# Altium schematic sheet RPI1.SchDoc
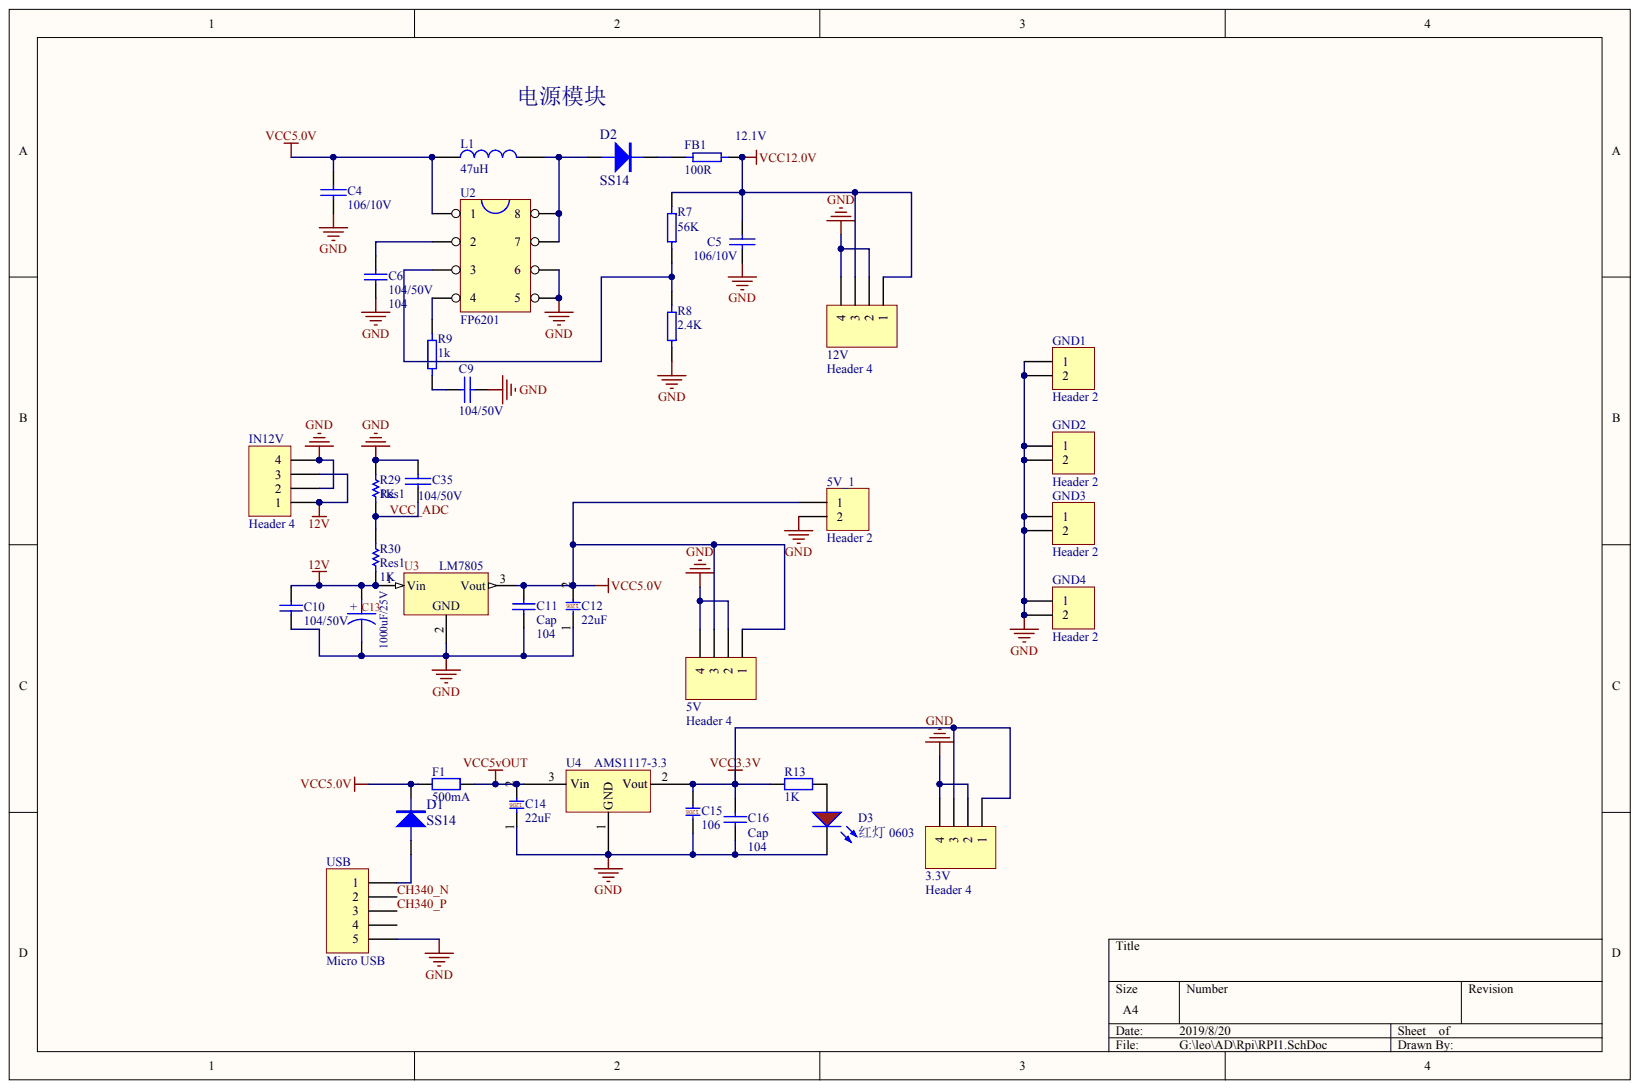
[diagram p0: RPI1.SchDoc]

## Parts
3.3V  Header 4  [KF350-4P]
5V  Header 4  [KF350-4P]
5V_1  Header 2  [HDR1X2]
12V  Header 4  [KF350-4P]
C4  106/10V  [1206c]
C5  106/10V  [1206c]
C6  104/50V  value 104  [0603C]
C9  104/50V  [0603C]
C10  104/50V  [0805]
C11  Cap  value 104  [0805C]
C12  22uF  [1206c]
C13  1000uF/25V  [CAP-10x20-5mm - Vertical]
C14  22uF  [1206c]
C15  106  [1206C]
C16  Cap  value 104  [0805C]
C35  104/50V  [0805c]
D1  SS14  [SMA]
D2  SS14  [SMA]
D3  红灯 0603  [0603_LED_S1]
F1  500mA  [0805FUSE]
FB1  100R  [1206R]
GND1  Header 2  [HDR1X2]
GND2  Header 2  [HDR1X2]
GND3  Header 2  [HDR1X2]
GND4  Header 2  [HDR1X2]
IN12V  Header 4  [KF350-4P]
L1  47uH  [L 50*40]
R7  56K  [0603R]
R8  2.4K  [0603R]
R9  1k  [0603R]
R13  1K  [0603R]
R29  Res1  value 1K  [0805R]
R30  Res1  value 1K  [0805R]
U2  FP6201  [SO-8]
U3  LM7805  [TO252]
U4  AMS1117-3.3  [SOT-223]
USB  Micro USB  [Micro USB]

## Nets
12V: C10.1 C13.1 IN12V.1 IN12V.3 R30.1 U3.1
GND: 3.3V.2 3.3V.4 5V.2 5V.4 5V_1.2 12V.2 12V.4 C4.2 C5.2 C6.2 C9.1 C10.2 C11.2 C12.1 C13.2 C14.1 C15.1 C16.2 C35.2 D3.K GND1.1 GND1.2 GND2.1 GND2.2 GND3.1 GND3.2 GND4.1 GND4.2 IN12V.2 IN12V.4 R8.1 R29.2 U2.5 U2.6 U3.2 U4.1 USB.5
VCC3.3V: 3.3V.1 3.3V.3 C15.2 C16.1 R13.2 U4.2
VCC5.0V: 5V.1 5V.3 5V_1.1 C4.1 C11.1 C12.2 D1.2 F1.2 L1.1 U2.1 U3.3
VCC5VOUT: C14.2 F1.1 U4.3
VCC12.0V: 12V.1 12V.3 C5.1 FB1.1 R7.2
CH340_N: USB.2
CH340_P: USB.3
VCC_ADC: C35.1 R29.1 R30.2
NetC6_1: C6.1 U2.2
NetC9_2: C9.2 R9.1
NetD1_1: D1.1 USB.1
NetD2_1: D2.1 L1.2 U2.7 U2.8
NetD2_2: D2.2 FB1.2
NetD3_A: D3.A R13.1
NetR7_1: R7.1 R8.2 U2.3
NetR9_2: R9.2 U2.4

Not connected: USB 4

## Library data of the parts
3.3V 5V 12V IN12V = Header 4: Header, 4-Pin; Component Kind: Standard; LatestRevisionDate: 17-Jul-2002; LatestRevisionNote: Re-released for DXP Platform.; Library Name: Miscellaneous Connectors.IntLib; Signal Integrity: Connector; Publisher: Altium Limited
5V_1 GND1-GND4 = Header 2: Header, 2-Pin; Component Kind: Standard; LatestRevisionDate: 17-Jul-2002; LatestRevisionNote: Re-released for DXP Platform.; Library Name: Miscellaneous Connectors.IntLib; Signal Integrity: Connector; Publisher: Altium Limited
C4 C5 C9 C13 C35 = CAP: Capacitor; Component Kind: Standard
  C4 C5: Library Name: Wireless module.SCHLIB
  C13: Library Name: Commonly used libraries.SCHLIB
C6 C10 C11 C16 = Cap: Capacitor; Component Kind: Standard; LatestRevisionDate: 17-Jul-2002; LatestRevisionNote: Re-released for DXP Platform.; Library Name: Miscellaneous Devices.IntLib; PackageReference: RAD-0.3; Published: 8-Jun-2000; Signal Integrity: Cap; Simulation: CAP; Publisher: Altium Limited
C12 C14 C15 = Cap_1206: Capacitor; Component Kind: Standard; Library Name: LZ PcbLib - ALL.SchLib
D1 D2 = DIODE: Component Kind: Standard; Description: Diode; Library Name: Wireless module.SCHLIB
D3 = 0603 红灯: 发光二极管; Component Kind: Standard; ComponentLink1Description: 供应商链接; ComponentLink1URL: http://www.szlcsc.com/product/details_2659.html; Library Name: LED.SchLib; Package: 0603; Supplier: LC; SuppliersPartNumber: C2286
F1 R13 = Res2: Resistor; Component Kind: Standard; LatestRevisionDate: 17-Jul-2002; LatestRevisionNote: Re-released for DXP Platform.; PackageReference: AXIAL-0.4; Published: 8-Jun-2000; Publisher: Altium Limited
FB1 R7-R9 = RES: Component Kind: Standard; Library Name: Wireless module.SCHLIB
L1 = INDUCTOR: Component Kind: Standard
R29 R30 = Res1: Resistor; Component Kind: Standard; LatestRevisionDate: 17-Jul-2002; LatestRevisionNote: Re-released for DXP Platform.; Library Name: Miscellaneous Devices.IntLib; PackageDescription: Axial Device, Thru-Hole; 2 Leads; 0.3 in Pin Spacing; PackageReference: AXIAL-0.3; Published: 8-Jun-2000; Signal Integrity: Res; Simulation: RESISTOR; Publisher: Altium Limited
U2 = IC-8P: Component Kind: Standard
U3 = LM7805: 3-Terminal Positive Voltage Regulator; Component Kind: Standard; Library Name: Commonly used libraries.SCHLIB
U4 = Component_1: Component Kind: Standard; Library Name: Schlib1.SchLib
USB = Header 5: Header, 5-Pin; Component Kind: Standard; LatestRevisionDate: 17-Jul-2002; LatestRevisionNote: Re-released for DXP Platform.; Library Name: Miscellaneous Connectors.IntLib; Signal Integrity: Connector; Publisher: Altium Limited
